# Altium schematic sheet 飞控主板.SchDoc
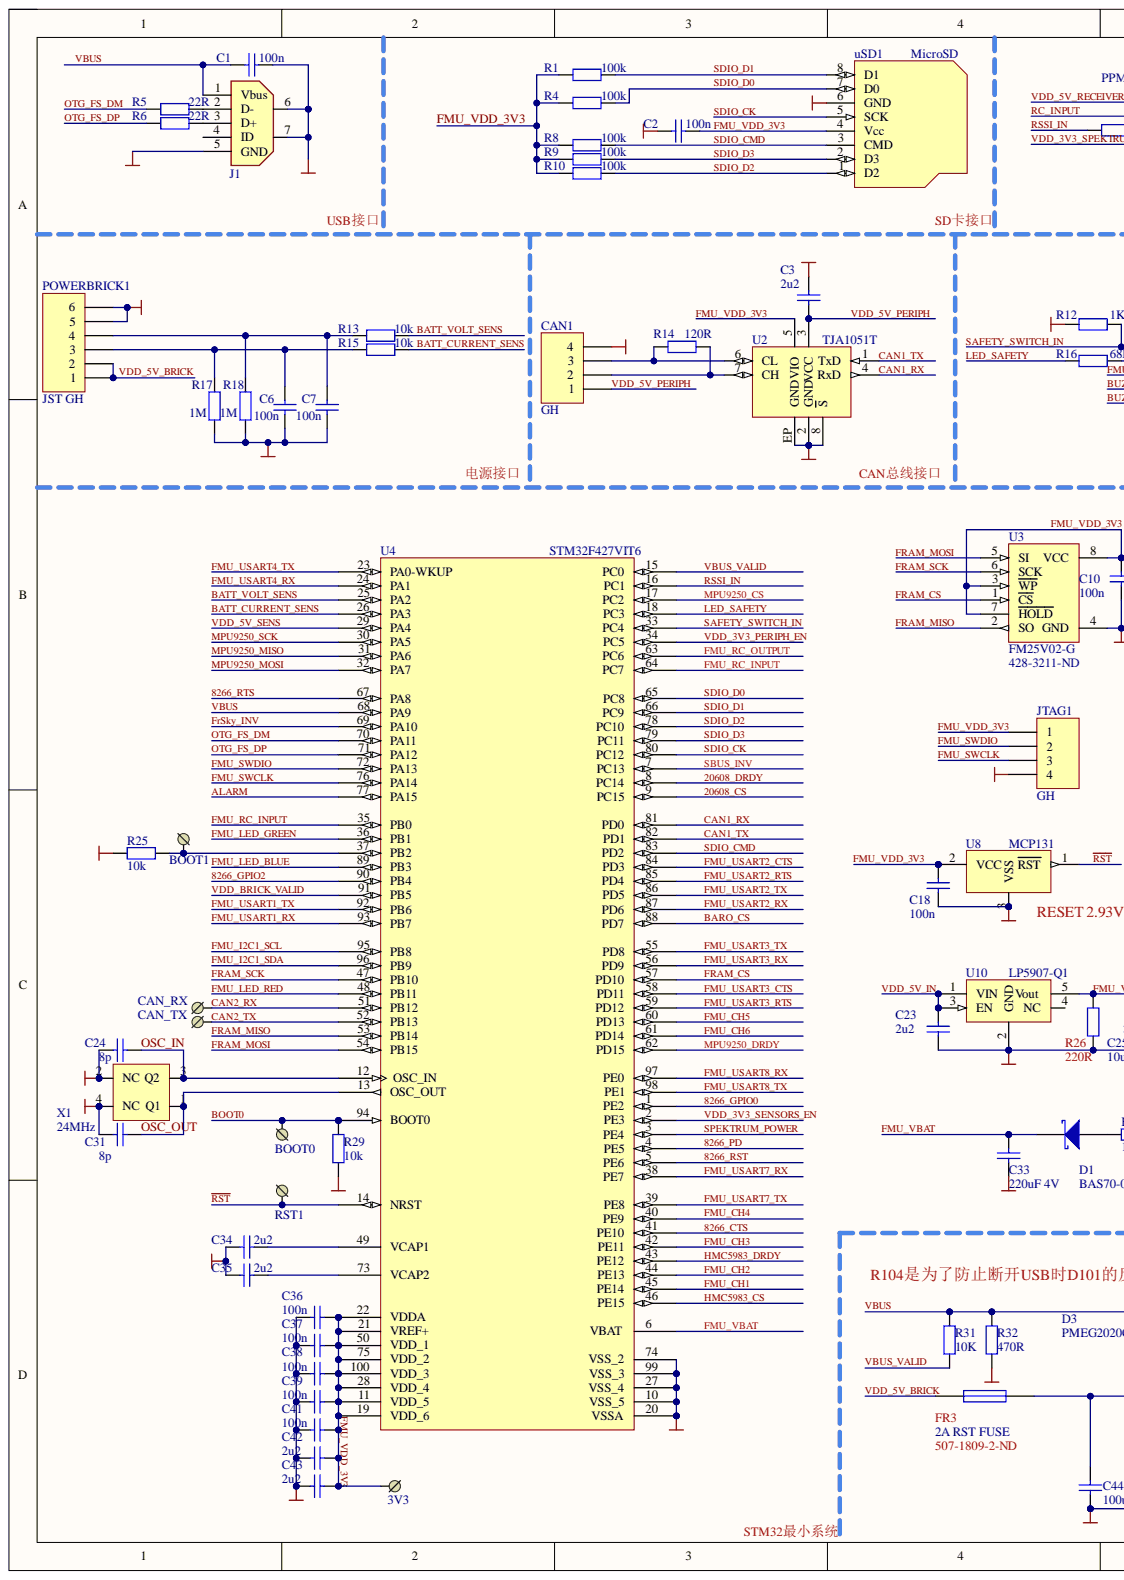
[diagram p0: 飞控主板.SchDoc, left]
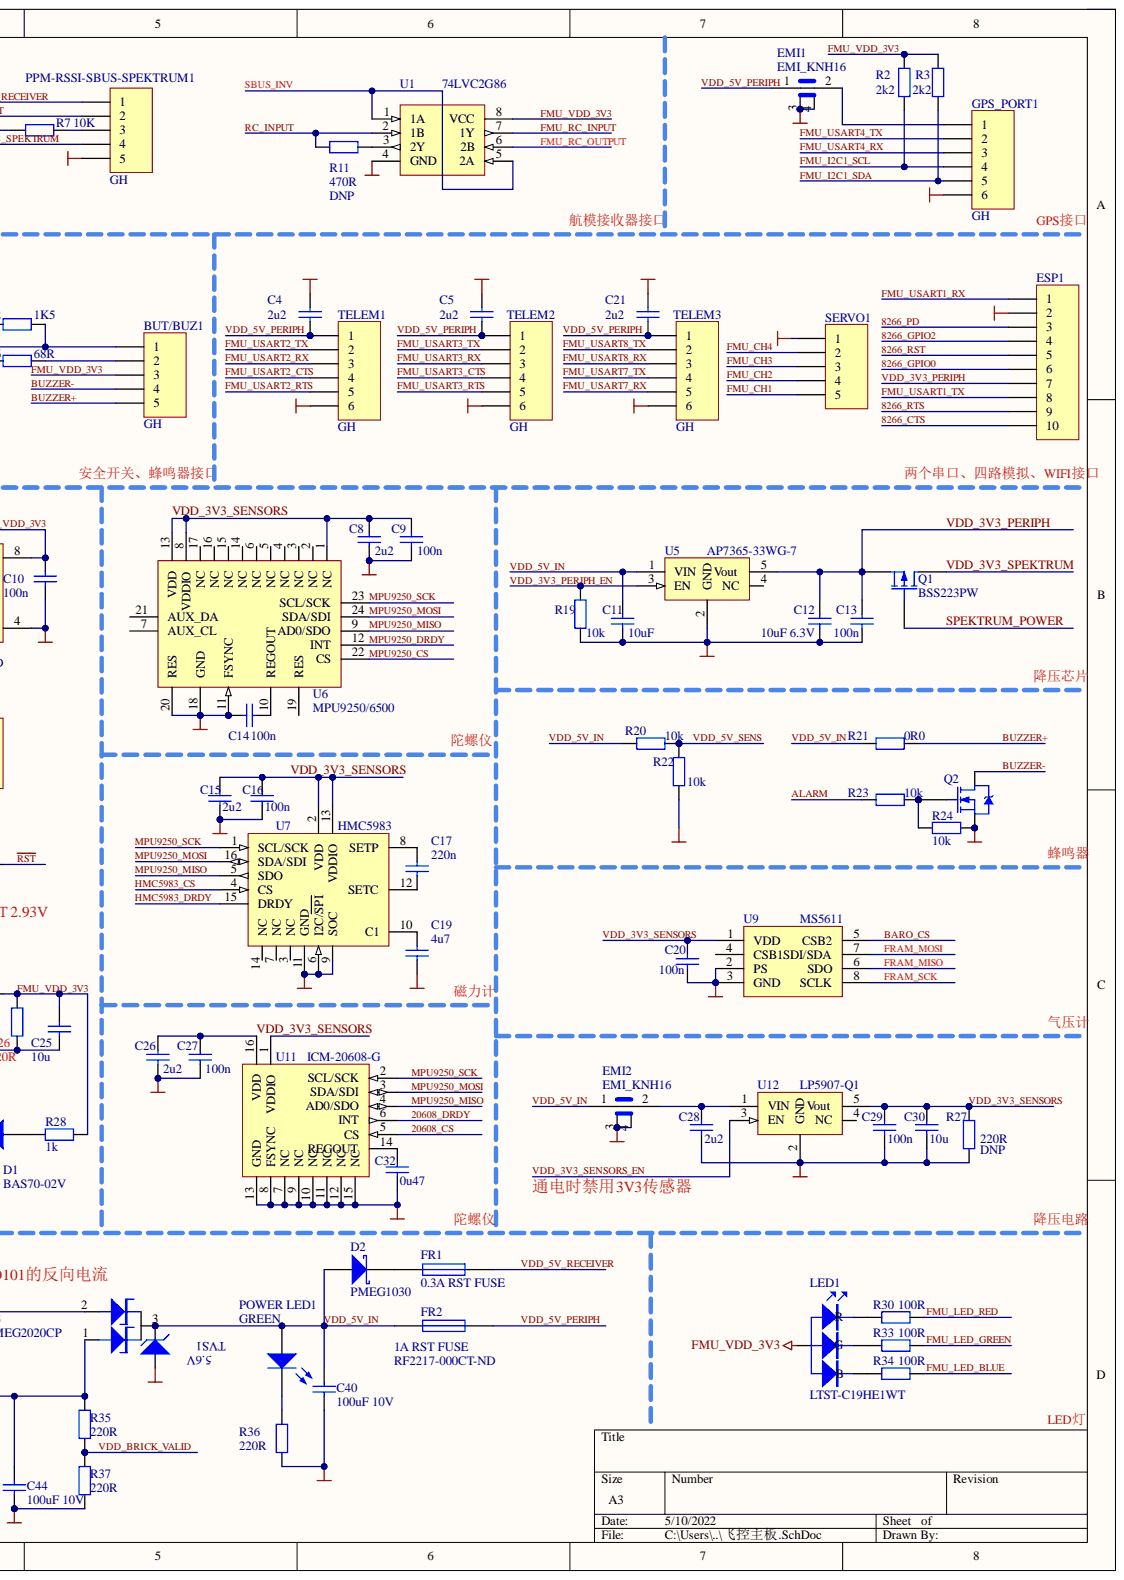
[diagram p1: 飞控主板.SchDoc, right]

## Parts
3V3  3V3  [PAD1]
BOOT0  BOOT  [PAD1]
BOOT1  BOOT1  [PAD1]
BUT/BUZ1  GH  [Molex_Clik_1.25_5H]
C1  100n  [0402A]
C2  100n  [0402A]
C3  2u2  [0603A]
C4  2u2  [0402A]
C5  2u2  [0402A]
C6  100n  [0402A]
C7  100n  [0402A]
C8  2u2  [0402A]
C9  100n  [0402A]
C10  100n  [0402A]
C11  10uF  [0603A]
C12  10uF 6.3V  [0603A]
C13  100n  [0603A]
C14  100n  [0402A]
C15  2u2  [0402A]
C16  100n  [0402A]
C17  220n  [0402A]
C18  100n  [0402A]
C19  4u7  [0603A]
C20  100n  [0402A]
C21  2u2  [0402A]
C23  2u2  [0603A]
C24  8p  [0603A]
C25  10u  [0603A]
C26  2u2  [0402A]
C27  100n  [0402A]
C28  2u2  [0603A]
C29  100n  [0603A]
C30  10u  [0603A]
C31  8p  [0603A]
C32  0u47  [0402A]
C33  220uF 4V  [1206A]
C34  2u2  [0402A]
C35  2u2  [0402A]
C36  100n  [0402A]
C37  100n  [0402A]
C38  100n  [0402A]
C39  100n  [0402A]
C40  100uF 10V  [0805A]
C41  100n  [0402A]
C42  2u2  [0402A]
C43  2u2  [0402A]
C44  100uF 10V  [0805A]
CAN1  GH  [Molex_1.25_click_4]
CAN_RX  CAN2_RX  [PAD1]
CAN_TX  CAN2_TX  [PAD1]
D1  BAS70-02V  [SOD-523]
D2  PMEG1030  [sod323f]
D3  PMEG2020CP  [pmeg2020cp]
EMI1  EMI_KNH16  [EMI_KNH16_0603]
EMI2  EMI_KNH16  [EMI_KNH16_0603]
ESP1  (no value)  [2.54mm_10pin_SMD]
FR1  0.3A RST FUSE  [0805A]
FR2  1A RST FUSE  DigiKey: RF2217-000CT-ND  [0805A]
FR3  2A RST FUSE  [1206A]
GPS_PORT1  GH  [Molex_Clok_1.25_6H]
J1  miniUSB  [USBmicro_B]  (value not drawn)
JTAG1  GH  [Molex_1.25_click_4]
LED1  LTST-C19HE1WT  [LTST-C19HE1WT]
POWER_LED1  GREEN  [0603A]
POWERBRICK1  JST GH  [Molex_Clok_1.25_6H]
PPM-RSSI-SBUS-SPEKTRUM1  GH  [Molex_Clik_1.25_5H]
Q1  BSS223PW  [2N7002-SOT323]
Q2  DMG1012UW  [2N7002-SOT323]  (value not drawn)
R1  100k  [0402A]
R2  2k2  [0402A]
R3  2k2  [0402A]
R4  100k  [0402A]
R5  22R  [0402A]
R6  22R  [0402A]
R7  10K  [0402A]
R8  100k  [0402A]
R9  100k  [0402A]
R10  100k  [0402A]
R11  470R  [0402A]
R12  1K5  [0402A]
R13  10k  [0402A]
R14  120R  [0603A]
R15  10k  [0402A]
R16  68R  [0402A]
R17  1M  [0402A]
R18  1M  [0402A]
R19  10k  [0603A]
R20  10k  [0402A]
R21  0R0  [0402A]
R22  10k  [0402A]
R23  10k  [0402A]
R24  10k  [0402A]
R25  10k  [0402A]
R26  220R  [0603A]
R27  220R  REM: DNP  [0402A]
R28  1k  [0603A]
R29  10k  [0402A]
R30  100R  [0402A]
R31  10K  [0603A]
R32  470R  [0603A]
R33  100R  [0402A]
R34  100R  [0402A]
R35  220R  [0603A]
R36  220R  [0603A]
R37  220R  [0603A]
RST1  BOOT  [PAD1]
SERVO1  (no value)  [Molex_Clik_1.25_5H]
TELEM1  GH  [Molex_Clok_1.25_6H]
TELEM2  GH  [Molex_Clok_1.25_6H]
TELEM3  GH  [Molex_Clok_1.25_6H]
TVS1  5.6V  [SOD123F]
U1  74LVC2G86  [DCU(SM-8)]
U2  TJA1051T  [8DFN_3x3]
U3  FM25V02-G  Supplier Part Number: 428-3211-ND  [SO-8_N]
U4  STM32F427VIT6  [LQFP100_L]
U5  AP7365-33WG-7  [SOT23-5]
U6  MPU9250/6500  [MPU6500-9250]
U7  HMC5983  [HMC5883L]
U8  MCP131  [SOT-323]
U9  MS5611  [MS5611]
U10  LP5907-Q1  [SOT23-5]
U11  ICM-20608-G  [HCLGA-16L]
U12  LP5907-Q1  [SOT23-5]
uSD1  MicroSD  [MicroSD_China_01]
X1  24MHz  [Crystal_2.5x2]

## Nets
GND: C1.1 C2.2 C3.1 C4.2 C5.2 C6.2 C7.2 C8.1 C9.1 C10.1 C11.1 C12.1 C13.1 C14.1 C15.1 C16.1 C18.1 C19.1 C20.1 C21.2 C23.1 C24.1 C25.1 C26.1 C27.1 C28.1 C29.1 C30.1 C31.1 C32.1 C33.1 C34.2 C35.2 C36.1 C37.1 C38.1 C39.1 C40.1 C41.1 C42.1 C43.1 C44.1 CAN1.4 EMI1.3 EMI1.4 EMI2.3 EMI2.4 ESP1.2 GPS_PORT1.6 J1.5(GND) J1.6 J1.7 JTAG1.4 POWERBRICK1.5 POWERBRICK1.6 PPM-RSSI-SBUS-SPEKTRUM1.5 Q2.2 R12.2 R17.2 R18.2 R19.1 R22.2 R24.2 R25.1 R26.1 R27.1 R29.2 R32.1 R36.1 R37.2 SERVO1.1 TELEM1.6 TELEM2.6 TELEM3.6 TVS1.1 U1.4(GND) U2.2(GND) U2.8(S) U2.EP(GND) U3.4(GND) U4.10(VSS_5) U4.20(VSSA) U4.27(VSS_4) U4.74(VSS_2) U4.99(VSS_3) U5.2(GND) U6.11(FSYNC) U6.18(GND) U6.20(RES) U7.6(I2C/SPI) U7.9(SOC) U7.11(GND) U8.3 U9.2(PS) U9.3(GND) U10.2(GND) U11.7(NC) U11.8(FSYNC) U11.9(NC) U11.10(NC) U11.11(NC) U11.12(NC) U11.13(GND) U11.15(NC) U12.2(GND) uSD1.6(GND) X1.2(NC) X1.4(NC)
8266_CTS: ESP1.10 U4.41(PE10)
8266_GPIO0: ESP1.6 U4.1(PE2)
8266_GPIO2: ESP1.4 U4.90(PB4)
8266_PD: ESP1.3 U4.4(PE5)
8266_RST: ESP1.5 U4.5(PE6)
8266_RTS: ESP1.9 U4.67(PA8)
20608_CS: U4.9(PC15) U11.5(CS)
20608_DRDY: U4.8(PC14) U11.6(INT)
~{RST}: RST1.1 U4.14(NRST) U8.1
ALARM: R23.2 U4.77(PA15)
BARO_CS: U4.88(PD7) U9.5(CSB2)
BATT_CURRENT_SENS: R15.1 U4.26(PA3)
BATT_VOLT_SENS: R13.1 U4.25(PA2)
BOOT0: BOOT0.1 R29.1 U4.94(BOOT0)
BUZZER+: BUT/BUZ1.5 R21.1
BUZZER-: BUT/BUZ1.4 Q2.1
CAN1_RX: U2.4(RxD) U4.81(PD0)
CAN1_TX: U2.1(TxD) U4.82(PD1)
CAN2_RX: CAN_RX.1 U4.51(PB12)
CAN2_TX: CAN_TX.1 U4.52(PB13)
FMU_CH1: SERVO1.5 U4.45(PE14)
FMU_CH2: SERVO1.4 U4.44(PE13)
FMU_CH3: SERVO1.3 U4.42(PE11)
FMU_CH4: SERVO1.2 U4.40(PE9)
FMU_CH5: U4.60(PD13)
FMU_CH6: U4.61(PD14)
FMU_I2C1_SCL: GPS_PORT1.4 R2.2 U4.95(PB8)
FMU_I2C1_SDA: GPS_PORT1.5 R3.2 U4.96(PB9)
FMU_LED_BLUE: R34.1 U4.89(PB3)
FMU_LED_GREEN: R33.1 U4.36(PB1)
FMU_LED_RED: R30.1 U4.48(PB11)
FMU_RC_INPUT: U1.7(1Y) U4.35(PB0) U4.64(PC7)
FMU_RC_OUTPUT: U1.6(2B) U4.63(PC6)
FMU_SWCLK: JTAG1.3 U4.76(PA14)
FMU_SWDIO: JTAG1.2 U4.72(PA13)
FMU_USART1_RX: ESP1.1 U4.93(PB7)
FMU_USART1_TX: ESP1.8 U4.92(PB6)
FMU_USART2_CTS: TELEM1.4 U4.84(PD3)
FMU_USART2_RTS: TELEM1.5 U4.85(PD4)
FMU_USART2_RX: TELEM1.3 U4.87(PD6)
FMU_USART2_TX: TELEM1.2 U4.86(PD5)
FMU_USART3_CTS: TELEM2.4 U4.58(PD11)
FMU_USART3_RTS: TELEM2.5 U4.59(PD12)
FMU_USART3_RX: TELEM2.3 U4.56(PD9)
FMU_USART3_TX: TELEM2.2 U4.55(PD8)
FMU_USART4_RX: GPS_PORT1.3 U4.24(PA1)
FMU_USART4_TX: GPS_PORT1.2 U4.23(PA0-WKUP)
FMU_USART7_RX: TELEM3.5 U4.38(PE7)
FMU_USART7_TX: TELEM3.4 U4.39(PE8)
FMU_USART8_RX: TELEM3.3 U4.97(PE0)
FMU_USART8_TX: TELEM3.2 U4.98(PE1)
FMU_VBAT: C33.2 D1.2 U4.6(VBAT)
FMU_VDD_3V3: 3V3.1 BUT/BUZ1.3 C2.1 C10.2 C18.2 C25.2 C36.2 C37.2 C38.2 C39.2 C41.2 C42.2 C43.2 JTAG1.1 LED1.4 R1.2 R2.1 R3.1 R4.2 R8.2 R9.2 R10.2 R26.2 R28.1 U1.8(VCC) U2.5(VIO) U3.3(WP) U3.7(HOLD) U3.8(VCC) U4.11(VDD_5) U4.19(VDD_6) U4.21(VREF+) U4.22(VDDA) U4.28(VDD_4) U4.50(VDD_1) U4.75(VDD_2) U4.100(VDD_3) U8.2 U10.5(Vout) uSD1.4(Vcc)
FRAM_CS: U3.1(CS) U4.57(PD10)
FRAM_MISO: U3.2(SO) U4.53(PB14) U9.6(SDO)
FRAM_MOSI: U3.5(SI) U4.54(PB15) U9.7(SDI/SDA)
FRAM_SCK: U3.6(SCK) U4.47(PB10) U9.8(SCLK)
FrSky_INV: U4.69(PA10)
HMC5983_CS: U4.46(PE15) U7.4(CS)
HMC5983_DRDY: U4.43(PE12) U7.15(DRDY)
LED_SAFETY: R16.2 U4.18(PC3)
MPU9250_CS: U4.17(PC2) U6.22(CS)
MPU9250_DRDY: U4.62(PD15) U6.12(INT)
MPU9250_MISO: U4.31(PA6) U6.9(AD0/SDO) U7.5(SDO) U11.4(AD0/SDO)
MPU9250_MOSI: U4.32(PA7) U6.24(SDA/SDI) U7.16(SDA/SDI) U11.3(SDA/SDI)
MPU9250_SCK: U4.30(PA5) U6.23(SCL/SCK) U7.1(SCL/SCK) U11.2(SCL/SCK)
OSC_IN: C24.2 U4.12(OSC_IN) X1.3(Q2)
OSC_OUT: C31.2 U4.13(OSC_OUT) X1.1(Q1)
OTG_FS_DM: R5.2 U4.70(PA11)
OTG_FS_DP: R6.2 U4.71(PA12)
RC_INPUT: PPM-RSSI-SBUS-SPEKTRUM1.2 R11.2 U1.2(1B)
RSSI_IN: R7.2 U4.16(PC1)
SAFETY_SWITCH_IN: BUT/BUZ1.1 R12.1 U4.33(PC4)
SBUS_INV: U1.1(1A) U1.5(2A) U4.7(PC13)
SDIO_CK: U4.80(PC12) uSD1.5(SCK)
SDIO_CMD: R8.1 U4.83(PD2) uSD1.3(CMD)
SDIO_D0: R4.1 U4.65(PC8) uSD1.7(D0)
SDIO_D1: R1.1 U4.66(PC9) uSD1.8(D1)
SDIO_D2: R10.1 U4.78(PC10) uSD1.1(D2)
SDIO_D3: R9.1 U4.79(PC11) uSD1.2(D3)
SPEKTRUM_POWER: Q1.3 U4.3(PE4)
VBUS: C1.2 D3.2 J1.1(Vbus) R31.2 R32.2 U4.68(PA9)
VBUS_VALID: R31.1 U4.15(PC0)
VDD_3V3_PERIPH: C12.2 C13.2 ESP1.7 Q1.2 U5.5(Vout)
VDD_3V3_PERIPH_EN: R19.2 U4.34(PC5) U5.3(EN)
VDD_3V3_SENSORS: C8.2 C9.2 C15.2 C16.2 C20.2 C26.2 C27.2 C29.2 C30.2 R27.2 U6.1(NC) U6.8(VDDIO) U6.13(VDD) U7.2(VDD) U7.13(VDDIO) U9.1(VDD) U11.16(VDD) U12.5(Vout)
VDD_3V3_SENSORS_EN: U4.2(PE3) U12.3(EN)
VDD_3V3_SPEKTRUM: PPM-RSSI-SBUS-SPEKTRUM1.4 Q1.1
VDD_5V_BRICK: FR3.1 POWERBRICK1.1 POWERBRICK1.2
VDD_5V_IN: C11.2 C23.2 C40.2 D2.1 D3.3 EMI2.1 FR2.1 POWER_LED1.1 R20.2 R21.2 TVS1.2 U5.1(VIN) U10.1(VIN) U10.3(EN)
VDD_5V_PERIPH: C3.2 C4.1 C5.1 C21.1 CAN1.1 EMI1.1 FR2.2 TELEM1.1 TELEM2.1 TELEM3.1 U2.3(VCC)
VDD_5V_RECEIVER: FR1.2 PPM-RSSI-SBUS-SPEKTRUM1.1
VDD_5V_SENS: R20.1 R22.1 U4.29(PA4)
VDD_BRICK_VALID: R35.2 R37.1 U4.91(PB5)
NetBOOT1_1: BOOT1.1 R25.2 U4.37(PB2)
NetBUT/BUZ1_2: BUT/BUZ1.2 R16.1
NetC6_1: C6.1 POWERBRICK1.3 R15.2 R17.1
NetC7_1: C7.1 POWERBRICK1.4 R13.2 R18.1
NetC14_2: C14.2 U6.10(REGOUT)
NetC17_1: C17.1 U7.12(SETC)
NetC17_2: C17.2 U7.8(SETP)
NetC19_2: C19.2 U7.10(C1)
NetC28_2: C28.2 EMI2.2 U12.1(VIN)
NetC32_2: C32.2 U11.14(REGOUT)
NetC34_1: C34.1 U4.49(VCAP1)
NetC35_1: C35.1 U4.73(VCAP2)
NetC44_2: C44.2 D3.1 FR3.2 R35.1
NetCAN1_2: CAN1.2 R14.1 U2.7(CH)
NetCAN1_3: CAN1.3 R14.2 U2.6(CL)
NetD1_1: D1.1 R28.2
NetD2_2: D2.2 FR1.1
NetEMI1_2: EMI1.2 GPS_PORT1.1
NetJ1_2: J1.2(D-) R5.1
NetJ1_3: J1.3(D+) R6.1
NetLED1_1: LED1.1(R) R30.2
NetLED1_2: LED1.2(G) R33.2
NetLED1_3: LED1.3(B) R34.2
NetPOWER LED1_2: POWER_LED1.2 R36.2
NetPPM-RSSI-SBUS-SPEKTRUM1_3: PPM-RSSI-SBUS-SPEKTRUM1.3 R7.1
NetQ2_3: Q2.3 R23.1 R24.1
NetR11_1: R11.1 U1.3(2Y)

Not connected: J1 4(ID); U5 4(NC); U6 2(NC) 3(NC) 4(NC) 5(NC) 6(NC) 7(AUX_CL) 14(NC) 15(NC) 16(NC) 17(NC) 19(RES) 21(AUX_DA); U7 3(NC) 7(NC) 14(NC); U9 4(CSB1); U10 4(NC); U11 1(VDDIO); U12 4(NC)

## Library data of the parts
3V3 BOOT0 BOOT1 CAN_RX CAN_TX RST1 = PLUG: Component Kind: Standard; Library Name: My_Altium.SchLib
BUT/BUZ1 PPM-RSSI-SBUS-SPEKTRUM1 SERVO1 = Header 5: Header, 5-Pin; Component Kind: Standard; LatestRevisionDate: 17-Jul-2002; LatestRevisionNote: Re-released for DXP Platform.; Signal Integrity: Connector; Publisher: Altium Limited
  BUT/BUZ1 PPM-RSSI-SBUS-SPEKTRUM1: Library Name: Miscellaneous Connectors.IntLib
  SERVO1: Library Name: 原理图库.SCHLIB
C1-C21 C23-C44 = Cap: Capacitor; Value: 100pF; Component Kind: Standard; LatestRevisionDate: 17-Jul-2002; LatestRevisionNote: Re-released for DXP Platform.; Library Name: Miscellaneous Devices.IntLib; PackageReference: RAD-0.3; Published: 8-Jun-2000; Signal Integrity: Cap; Simulation: CAP; Publisher: Altium Limited
  C11-C13 C33 C40 C44: PackageDescription: Radial Cap, Thru-Hole; 2 Leads; 0.3 in Pin Spacing
CAN1 JTAG1 = Header 4: Header, 4-Pin; Component Kind: Standard; LatestRevisionDate: 17-Jul-2002; LatestRevisionNote: Re-released for DXP Platform.; Library Name: Miscellaneous Connectors.IntLib; Signal Integrity: Connector; Publisher: Altium Limited
D1 D2 = D Schottky: Schottky Diode; Code_JEDEC: DO-214-AA; Component Kind: Standard; LatestRevisionDate: 17-Jul-2002; LatestRevisionNote: Re-released for DXP Platform.; Library Name: Miscellaneous Devices.IntLib; PackageDescription: DO-214-AA/SMB; 2 C-Bend Leads; Body 5.3 x 3.6 mm, inc. leads (LxW); PackageReference: SMB; Published: 8-Jun-2000; Signal Integrity: D Schottky; Simulation: SKYDIODE; Publisher: Altium Limited
  D1: Mouser: 78-BAS70-02V-V-G-08
ESP1 = Header 10: Header, 10-Pin; Component Kind: Standard; LatestRevisionDate: 17-Jul-2002; LatestRevisionNote: Re-released for DXP Platform.; Library Name: Miscellaneous Connectors.IntLib; Signal Integrity: Connector; Publisher: Altium Limited
FR1-FR3 = Fuse 1: Fuse; Component Kind: Standard; LatestRevisionDate: 17-Jul-2002; LatestRevisionNote: Re-released for DXP Platform.; Library Name: Miscellaneous Devices.IntLib; PackageDescription: Thru-Hole; 2 Leads; PackageReference: PIN-W2/E2.8; Published: 8-Jun-2000; Simulation: FUSE; Publisher: Altium Limited
  FR3: DigiKey: 507-1809-2-ND
GPS_PORT1 POWERBRICK1 TELEM1-TELEM3 = Header 6: Header, 6-Pin; Component Kind: Standard; LatestRevisionDate: 17-Jul-2002; LatestRevisionNote: Re-released for DXP Platform.; Library Name: Miscellaneous Connectors.IntLib; Signal Integrity: Connector; Publisher: Altium Limited
J1 = MicroUSB: Component Kind: Standard; Library Name: My_Altium.SchLib; Mouser Part Number: 538-47346-0001
LED1 = RGB_LED_5MM: Component Kind: Standard; Library Name: My_Altium.SchLib
POWER_LED1 = LED0: Typical INFRARED GaAs LED; Component Kind: Standard; LatestRevisionDate: 08-Jul-2005; LatestRevisionNote: Mech Layer 1 removed.; Library Name: Miscellaneous Devices.IntLib; PackageDescription: LED; 2 Leads; PackageReference: LED-0; Published: 8-Jun-2000; Simulation: LED0; Publisher: Altium Limited
Q1 = MOSFET-P: P-Channel MOSFET; Component Kind: Standard; LatestRevisionDate: 17-Jul-2002; LatestRevisionNote: Re-released for DXP Platform.; Library Name: Miscellaneous Devices.IntLib; PackageDescription: TO, Flat Index; 3 In-Line, Axial Leads; Body Dia. 4.6mm; Lead Dia. 0.5 mm (max); PackageReference: E3; PackageVersion: 1995/1996; Published: 8-Jun-2000; Simulation: PMOS; Publisher: Altium Limited
Q2 = NMOS-2: N-Channel Power MOSFET; Note: Pin 2 is electrically connected to pin 4.; Code_JEDEC: TO-220-AB; Component Kind: Standard; ComponentLink1Description: Manufacturer Link; ComponentLink1URL: http://www.irf.com/; DatasheetDocument: PD-9.814; LatestRevisionDate: 17-Jul-2002; LatestRevisionNote: Re-released for DXP Platform.; Library Name: Miscellaneous Devices.IntLib; PackageReference: TO-220AB; Published: 8-Jun-2000; Simulation: IRF1010; Publisher: Altium Limited
R1-R10 R12-R27 R29-R37 = Res2: Resistor; Value: 1K; Component Kind: Standard; LatestRevisionDate: 17-Jul-2002; LatestRevisionNote: Re-released for DXP Platform.; Library Name: Miscellaneous Devices.IntLib; PackageReference: AXIAL-0.4; Published: 8-Jun-2000; Signal Integrity: Res; Simulation: RESISTOR; Publisher: Altium Limited
  R1-R6 R8-R10 R13 R15 R17-R27 R29 R31 R32 R35-R37: PackageDescription: Axial Device, Thru-Hole; 2 Leads; 0.4 in Pin Spacing
R11 = Res2: Resistor; REM: DNP; Value: 1K; Component Kind: Standard; LatestRevisionDate: 17-Jul-2002; LatestRevisionNote: Re-released for DXP Platform.; Library Name: Miscellaneous Devices.IntLib; PackageReference: AXIAL-0.4; Published: 8-Jun-2000; Signal Integrity: Res; Simulation: RESISTOR; Publisher: Altium Limited
R28 = Res2: Resistor; Component Kind: Standard; LatestRevisionDate: 17-Jul-2002; LatestRevisionNote: Re-released for DXP Platform.; Library Name: Miscellaneous Devices.IntLib; PackageDescription: Axial Device, Thru-Hole; 2 Leads; 0.4 in Pin Spacing; PackageReference: AXIAL-0.4; Published: 8-Jun-2000; Signal Integrity: Res; Simulation: RESISTOR; Value: 1K; Publisher: Altium Limited
TVS1 = D Zener: Zener Diode; Component Kind: Standard; LatestRevisionDate: 17-Jul-2002; LatestRevisionNote: Re-released for DXP Platform.; Library Name: Miscellaneous Devices.IntLib; PackageDescription: Diode, Thru-Hole; 2 Leads; 0.7 in Pin Spacing; PackageReference: DIODE-0.7; Published: 8-Jun-2000; Signal Integrity: D Zener; Simulation: ZENER; Publisher: Altium Limited
U3 = AT45DB161D-MU: Component Kind: Standard; Library Name: My_Altium.SchLib
U4 = STM32F103VBT6: STM32 ARM-based 32-bit MCU with 64 Kbytes Flash, 100-pin LQFP, Industrial Temperature; Component Kind: Standard; ComponentLink1Description: Manufacturer Link; ComponentLink1URL: www.st.com/stm32; ComponentLink2Description: Datasheet; ComponentLink2URL: http://www.st.com/stonline/products/literature/ds/13587.pdf; DatasheetVersion: rev6, Mar-2008; Library Name: ST Microcontroller 32-Bit STM32.IntLib; PackageDescription: 100-Pin Low-Profile Quad Flat Pack, 14 x 14 mm Body, 0.5 mm Pitch (LQFP100); PackageReference: LQFP100; PackageVersion: Mar-2008; Published: 30-May-2008; Publisher: Altium Limited
U5 = LP5907-Q1: Component Kind: Standard; Library Name: My_Altium.SchLib
U6 = MPU6500: Component Kind: Standard; Library Name: My_Altium.SchLib
U8 = MCP102: Component Kind: Standard; Library Name: My_Altium.SchLib
U9 = MS5611-01BA03: Component Kind: Standard; Library Name: My_Altium.SchLib
X1 = Crystal_4pin: Component Kind: Standard; Library Name: My_Altium.SchLib; Supplier Part Number: 644-1052-1-ND
D3 = PMEG2020CP: Component Kind: Standard; Library Name: My_Altium.SchLib
EMI1 EMI2 = EMI_KNH16: Component Kind: Standard; Library Name: My_Altium.SchLib
U1 = 74LVC2G86: Component Kind: Standard; Library Name: My_Altium.SchLib
U2 = TJA1051T: Component Kind: Standard; Library Name: My_Altium.SchLib
U7 = HMC5983: Component Kind: Standard; Library Name: My_Altium.SchLib
U10 U12 = LP5907-Q1: Component Kind: Standard; Library Name: My_Altium.SchLib
U11 = ICM-20608-G: Component Kind: Standard; Library Name: My_Altium.SchLib
uSD1 = MicroSD: Component Kind: Standard; Library Name: My_Altium.SchLib
